# Altium schematic sheet CurrentSense.SchDoc
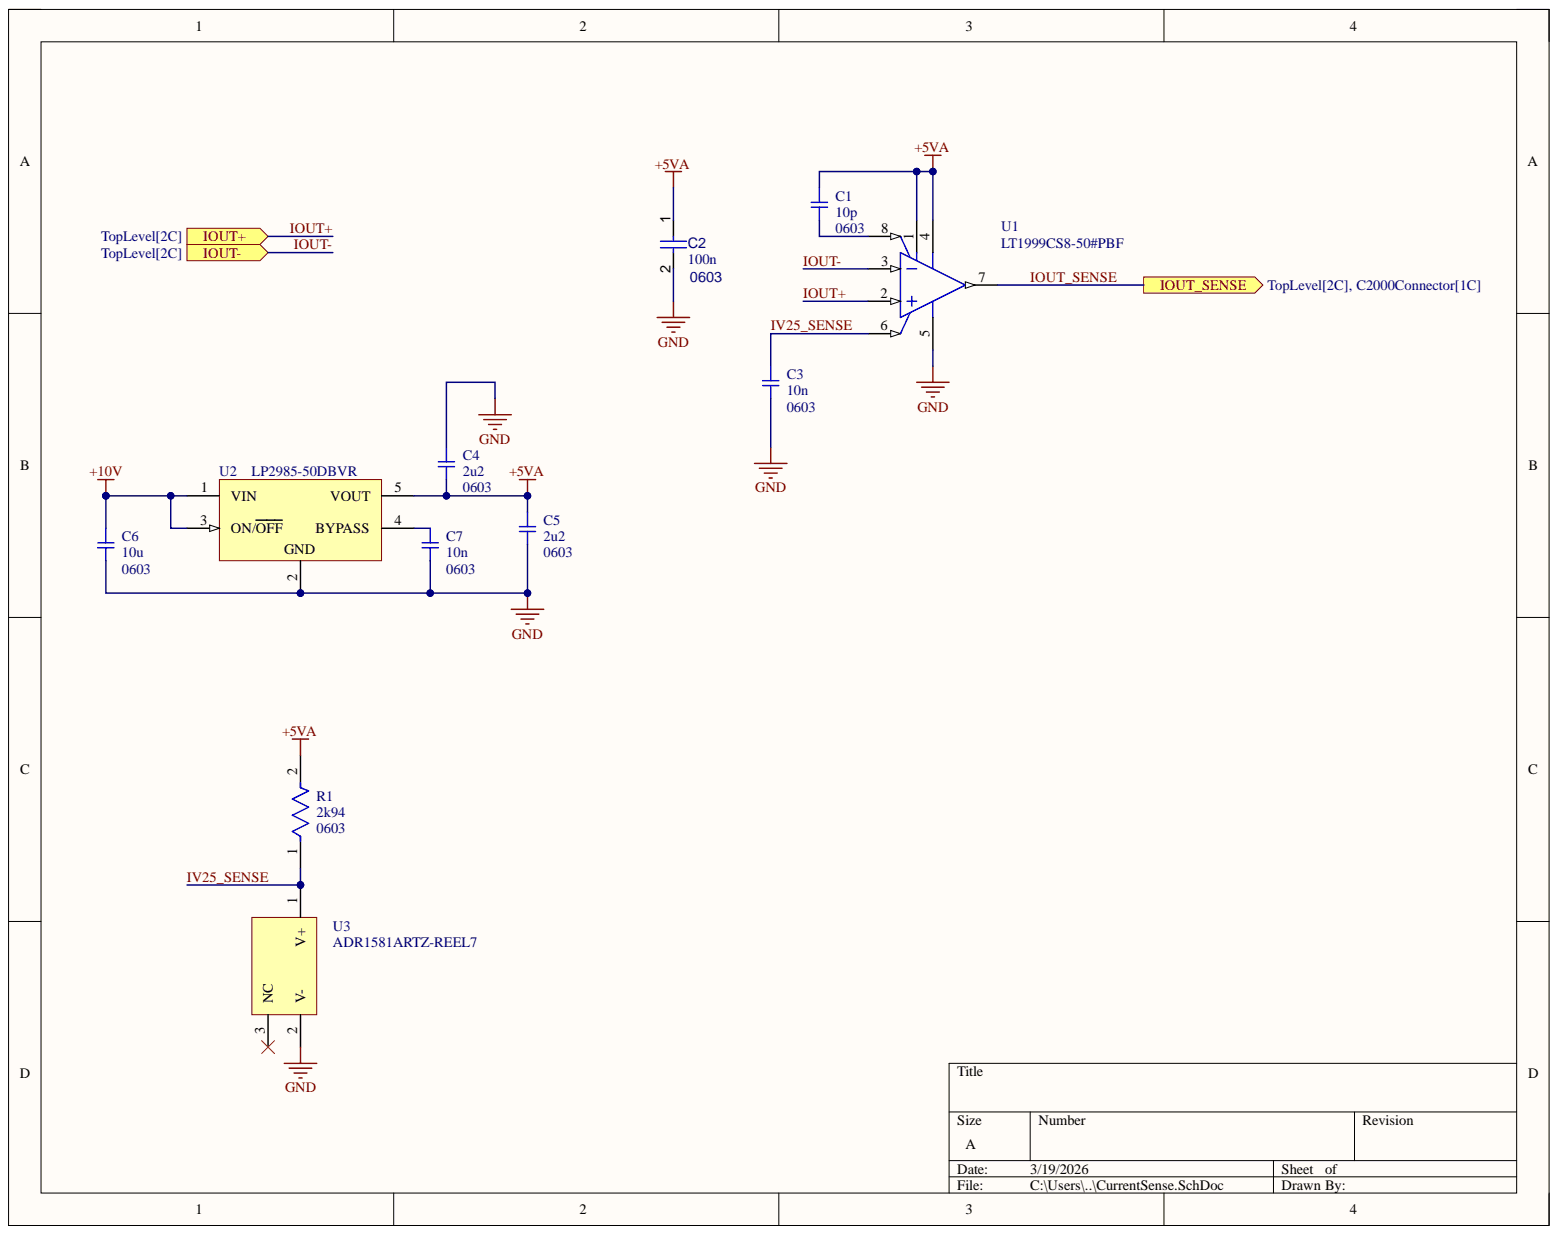
[diagram p0: CurrentSense.SchDoc]

## Parts
C1  0603  value 10p  [SMD-0603C]
C2  0603  value 100n  [CAPC1608X90X40NL10T20]
C3  0603  value 10n  [SMD-0603C]
C4  0603  value 2u2  [SMD-0603C]
C5  0603  value 2u2  [SMD-0603C]
C6  0603  value 10u  [SMD-0603C]
C7  0603  value 10n  [SMD-0603C]
R1  0603  Resistance: 2k94  [FP-CRCW0603-e3-IPC_B]
U1  LT1999CS8-50#PBF  [LT-S8-8_L]
U2  LP2985-50DBVR  [DBV0005A_M]
U3  ADR1581ARTZ-REEL7  [ADI-RT-3_M]

## Ports
IOUT+, IOUT-, IOUT_SENSE

## Nets
+5VA: C1.1 C2.1 C4.2 C5.1 R1.2 U1.1 U1.4 U2.5(VOUT)
+10V: C6.1 U2.1(VIN) U2.3(ON/OFF)
GND: C2.2 C3.2 C4.1 C5.2 C6.2 C7.2 U1.5 U2.2 U3.2
IOUT+: U1.2 ; port IOUT+
IOUT-: U1.3 ; port IOUT-
IOUT_SENSE: U1.7 ; port IOUT_SENSE
IV25_SENSE: C3.1 R1.1 U1.6 U3.1
NetC1_2: C1.2 U1.8
NetC7_1: C7.1 U2.4(BYPASS)
NetU3_3: U3.3

## Library data of the parts
C1 = CMP-003-000006-1: CAP CER 10PF 50V NP0 0603; Manufacturer: Murata Electronics North America; Part Number: GQM1885C1H100JB01D; @ qty: 0; Minimum Quantity: 1; Temperature Coefficient: C0G, NP0; Tolerance: ±5%; Unit Price (USD): 0.6; Applications: RF, Microwave, High Frequency; Component Kind: Standard; Component Type: Standard; Datasheets: http://www.murata.com/~/media/webrenewal/support/library/catalog/products/capacitor/mlcc/c02e.pdf; Design Item ID: GQM1885C1H100JB01D; Digi-Key Part Number: 490-3570-1-ND; Factory Stock: 0; Features: High Q, Low Loss; Height - Seated (Max): -; Image: //media.digikey.com/Renders/Murata%20Renders/0603%28LQG18%29.jpg; Lead Spacing: -; Lead Style: -; Mounting Type: Surface Mount, MLCC; Operating Temperature: -55°C ~ 125°C; Package / Case: 0603 (1608 Metric); Packaging: Cut Tape (CT); Part Status: Active; Pin Count: 2; Quantity Available: 26659; Ratings: -; Series: GQM; Size / Dimension: 0.063" L x 0.031" W (1.60mm x 0.80mm); Source: PV Buck v2.SCHLIB; Thickness (Max): 0.035" (0.90mm); Voltage: 50V; Library Name: Ishaan Gupta
C2 = CMP-001-00017-6: Chip Capacitor, 100 nF, +/- 10%, 50 V, 0603 (1608 Metric); Capacitor Type: Ceramic; Voltage Rating: 50V; Case/Package: 0603; Component Kind: Standard; Max Operating Temperature: 125°C; Min Operating Temperature: -55°C; Mounting Technology: SM; Pins: 2; RoHS Compliant: True; Tolerance: 10%; Library Name: Ishaan Gupta
C3 C7 = CMP-003-000014-1: CAP CER 10000PF 50V X7R 0603; Manufacturer: Murata Electronics North America; Part Number: GRM188R71H103KA01D; @ qty: 0; Minimum Quantity: 1; Tolerance: ±10%; Unit Price (USD): 0.1; Applications: General Purpose; Component Kind: Standard; Component Type: Standard; Datasheets: http://www.murata.com/~/media/webrenewal/support/library/catalog/products/capacitor/mlcc/c02e.pdf; Design Item ID: GRM188R71H103KA01D; Digi-Key Part Number: 490-1512-1-ND; Factory Stock: 0; Features: -; Height - Seated (Max): -; Image: //media.digikey.com/Renders/Murata%20Renders/ZRB-SERIES-0402.jpg; Lead Spacing: -; Lead Style: -; Mounting Type: Surface Mount, MLCC; Operating Temperature: -55°C ~ 125°C; Package / Case: 0603 (1608 Metric); Packaging: Cut Tape (CT); Part Status: Active; Pin Count: 2; Quantity Available: 3319587; Ratings: -; Series: GRM; Size / Dimension: 0.063" L x 0.031" W (1.60mm x 0.80mm); Source: PV Buck v2.SCHLIB; Temperature Coefficient: X7R; Thickness (Max): 0.035" (0.90mm); Voltage: 50V; Library Name: Ishaan Gupta
C4 C5 = CMP-003-000010-1: CAP CER 2.2UF 16V X5R 0603; Manufacturer: Murata Electronics North America; Part Number: GRM188R61C225KE15D; @ qty: 0; Minimum Quantity: 1; Tolerance: ±10%; Unit Price (USD): 0.12; Applications: General Purpose; Component Kind: Standard; Component Type: Standard; Datasheets: http://www.murata.com/~/media/webrenewal/support/library/catalog/products/capacitor/mlcc/c02e.pdf; Design Item ID: GRM188R61C225KE15D; Digi-Key Part Number: 490-3296-1-ND; Factory Stock: 0; Features: -; Height - Seated (Max): -; Image: //media.digikey.com/Renders/Murata%20Renders/ZRB-SERIES-0402.jpg; Lead Spacing: -; Lead Style: -; Mounting Type: Surface Mount, MLCC; Operating Temperature: -55°C ~ 85°C; Package / Case: 0603 (1608 Metric); Packaging: Cut Tape (CT); Part Status: Active; Pin Count: 2; Quantity Available: 1668676; Ratings: -; Series: GRM; Size / Dimension: 0.063" L x 0.031" W (1.60mm x 0.80mm); Source: PV Buck v2.SCHLIB; Temperature Coefficient: X5R; Thickness (Max): 0.035" (0.90mm); Voltage: 16V; Library Name: Ishaan Gupta
C6 = CMP-003-000015-1: CAP CER 10UF 16V X5R 0603; Manufacturer: Murata Electronics North America; Part Number: GRT188R61C106KE13D; @ qty: 0; Minimum Quantity: 1; Tolerance: ±10%; Unit Price (USD): 0.44; Applications: Automotive; Component Kind: Standard; Component Type: Standard; Datasheets: http://search.murata.co.jp/Ceramy/image/img/A01X/G101/ENG/GRT188R61C106KE13-01.pdf; Design Item ID: GRT188R61C106KE13D; Digi-Key Part Number: 490-12317-1-ND; Factory Stock: 0; Features: -; Height - Seated (Max): -; Image: //media.digikey.com/Renders/Murata%20Renders/0603%28LQG18%29.jpg; Lead Spacing: -; Lead Style: -; Mounting Type: Surface Mount, MLCC; Operating Temperature: -55°C ~ 85°C; Package / Case: 0603 (1608 Metric); Packaging: Cut Tape (CT); Part Status: Active; Pin Count: 2; Quantity Available: 19486; Ratings: AEC-Q200; Series: GRT; Size / Dimension: 0.063" L x 0.031" W (1.60mm x 0.80mm); Source: PV Buck v2.SCHLIB; Temperature Coefficient: X5R; Thickness (Max): 0.035" (0.90mm); Voltage: 16V; Library Name: Ishaan Gupta
R1 = CMP-009-000009-1: RES Thick Film, 2.94kO, 1%, 0.1W, 100ppm/°C, 0603; Manufacturer: Vishay; Manufacturer Part Number: CRCW06032K94FKEA; Max Power Dissipation: 100mW; Voltage Rating: 75V; Case Code (Imperial): 0603; Case Code (Metric): 1608; Case/Package: 0603; Component Kind: Standard; Component Type: Standard; Composition: Thick Film; Contact Plating: Tin; Depth: 0.85mm; Design Item ID: CMP-2000-03188-2; Features: Automotive AEC-Q200; Height: 0.45mm; Length: 1.55mm; Max Operating Temperature: 155°C; Military Standard: Not; Min Operating Temperature: -55°C; Number of Pins: 2; Number of Terminations: 2; Package Quantity: 10; Packaging: Tape and Reel; Pin Count: 2; Power Rating: 100mW; Radiation Hardening: No; Source: PV Buck v2.SCHLIB; Temperature Coefficient: 100ppm/°C; Termination: SMD/SMT; Tolerance: 1%; Weight: 7.1E-05oz; Width: 0.85mm; Library Name: Ishaan Gupta
U1 = CMP-0359-03365-2: Single Channel, High Voltage, Bidirectional Current Sense Amplifier, 2 MHz Typical GBW, 3 V/us Typical SR, 4.5 to 5.5 V, 8-Pin SOIC (S8-8), Commercial, Pb-Free; Linear Technology Part Number: LT1999-50; Manufacturer: Linear Technology; Channels (#): 1; GBW (MHz) Typical: 2; Is (mA) Typical: 1.55; SR (V/us) Typical: 3; Vos (mV) Typical: 0.5; Vs Max (V): 5.5; Vs Min (V): 4.5; Component Kind: Standard; ComponentLink1Description: Manufacturer URL; ComponentLink1URL: http://www.linear.com/; ComponentLink2Description: Datasheet; ComponentLink2URL: http://cds.linear.com/docs/en/datasheet/1999fb.pdf; ComponentLink3Description: Package Specification; ComponentLink3URL: http://cds.linear.com/docs/en/packaging/05081610_G_SO8.pdf; DatasheetVersion: Rev. B; Library Name: Altium Content Vault; Mounting Technology: Surface Mount; PackageDescription: 8-Pin Plastic Small Outline, Body 4.9 x 3.9 mm, Pitch 1.27 mm; PackageReference: LT-S8-8; PackageVersion: Rev G; PartNumber: LT1999CS8-50#PBF; RevisionNote: URLs updated; RoHS: Pb-Free; Type: CSA
U2 = CMP-019-00009-3: Low-Noise Low-Dropout Regulator with Shutdown, 150 mA, Fixed 5 V Output, 2.2 to 16 V Input, 5-Pin SOT-23 (DBV), -40 to 125 degC, Green (RoHS & no Sb/Br), Tape and Reel; Manufacturer: Texas Instruments; Dropout Voltage: 280mV; Max Input Voltage: 16V; Max Ouput Current: 150mA; Min Input Voltage: 2.2V; Nominal Output Voltage: 5V; Outputs: 1; Case/Package: SOT-23-5; Component Kind: Standard; Datasheet URL: http://www.ti.com/lit/ds/symlink/lp2985.pdf; Datasheet Version: SLVS522O, 01/2015; Manufacturer URL: http://www.ti.com/; Max Operating Temperature: 125°C; Min Operating Temperature: -40°C; Mounting Technology: SM; Output Current: 150mA; Output Voltage: 5V; Package Description: 5-Pin Small Outline Transistor, Body 2.9 x 1.6 mm, Pitch 0.95 mm; Package Quantity: 1; Package Reference: DBV0005A; Package URL: http://www.ti.com/lit/ml/mpds018l/mpds018l.pdf; Packaging: TapeandReel; Pins: 8; RoHS Compliant: True; Library Name: Ishaan Gupta
U3 = CMP-0784-00432-1: Micropower, Precision Shunt Voltage Reference, 1.25 V Output, Industrial, 3-pin SOT23 (RT-3), Reel; Manufacturer: Analog Devices; Ref Out TC (ppm/C): 100; Vout: 1.25V; Code_JEDEC: TO-236-AB; Component Kind: Standard; ComponentLink1Description: Manufacturer URL; ComponentLink1URL: http://www.analog.com/en/index.html; ComponentLink2Description: Datasheet; ComponentLink2URL: http://www.analog.com/static/imported-files/data_sheets/ADR1581.pdf; ComponentLink3Description: Package Specification; ComponentLink3URL: http:/www.analog.com/static/imported-files/packages/PKG_PDF/SOT-23-3 (RT)/RT_3.pdf; ComponentLink4Description: URL Link1; ComponentLink4URL: Product URL:http://www.analog.com/en/special-linear-functions/voltage-references/adr1581/products/product.html; DatasheetVersion: Rev. 0; Library Name: Altium Content Vault; Mounting Technology: Surface Mount; Operating Temp Range: -40 to 85 degC; PackageDescription: 3-Pin Small Outline Transistor Package, Body 2.9 x 1.3 mm, Pitch 0.96 mm; PackageReference: RT-3; PackageVersion: 011909-C, 02/2009; Packing: Reel; PartNumber: ADR1581ARTZ-REEL7
